# Altium schematic sheet [14] MISC.SchDoc("[14] MISC")
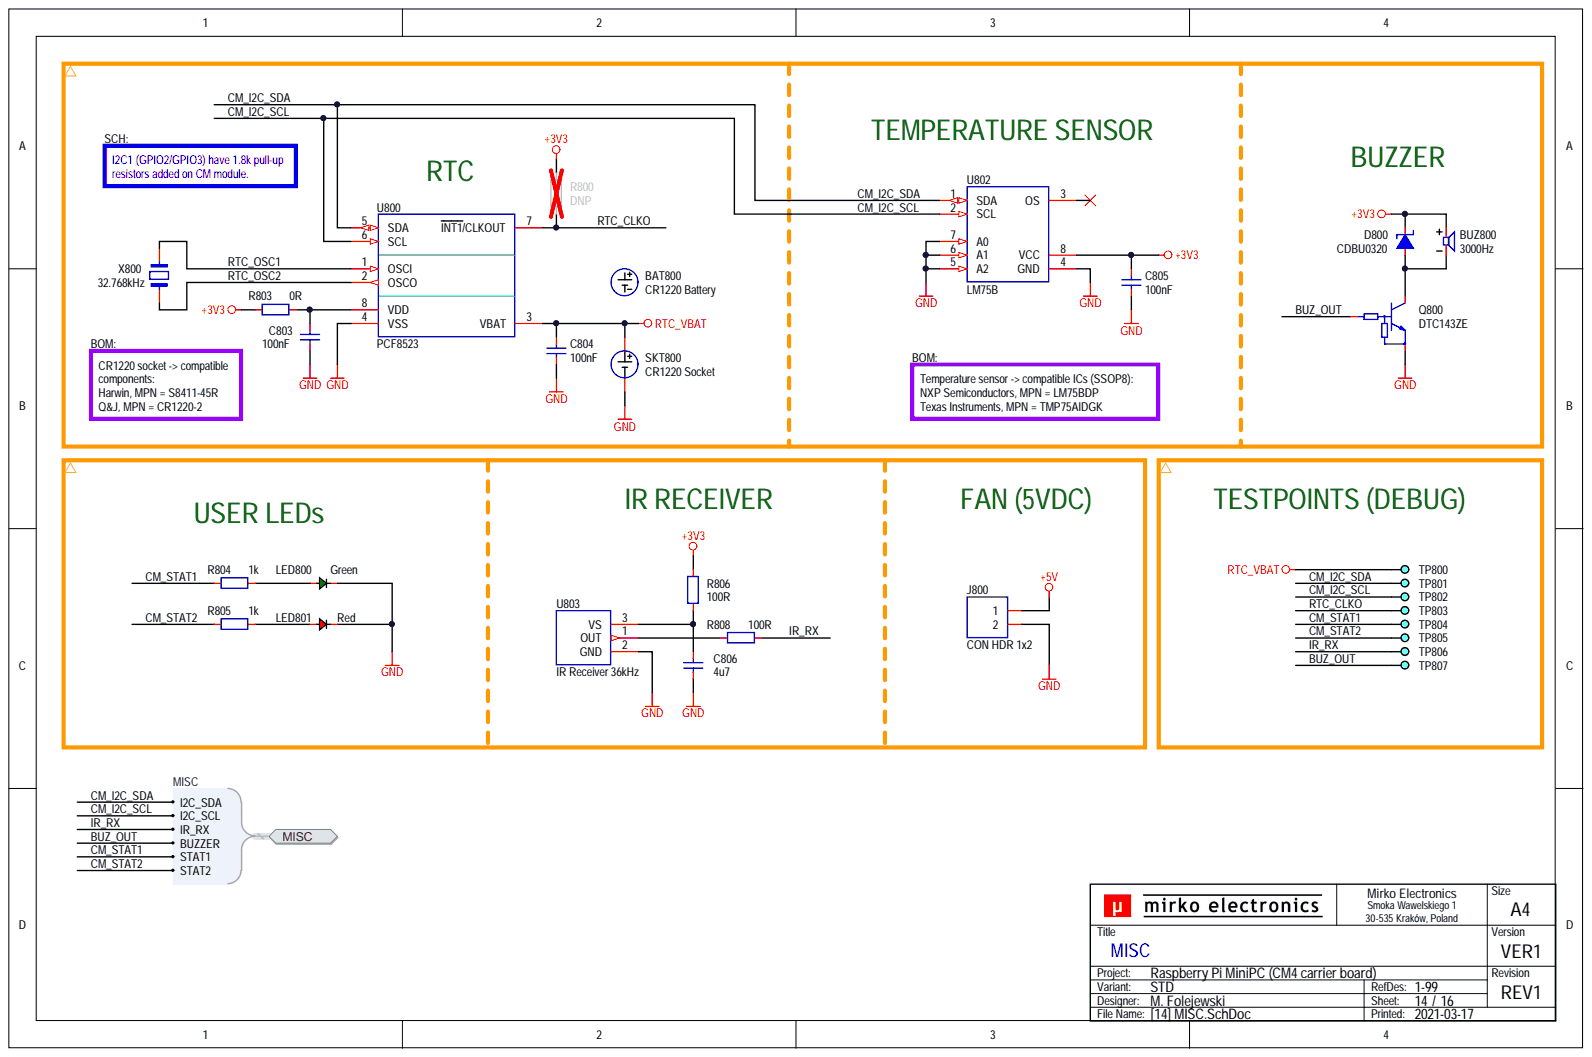
[diagram p0: [14] MISC.SchDoc]

## Parts
BAT800  CR1220 Battery  (mechanical)
BUZ800  3000Hz  [BUZ_960X960H500_R]
C803  100nF  [CAP_0603_R]
C804  100nF  [CAP_0603_R]
C805  100nF  [CAP_0603_R]
C806  4u7  [CAP_0603_R]
D800  CDBU0320  [DIO_0603_UNI_R]
J800  CON HDR 1x2  [CON_HDR_P254_1X02_STR]
LED800  Green  [LED_0805_GRN_R]
LED801  Red  [LED_0805_RED_R]
Q800  DTC143ZE  [PKG_SOT523_SC75_SOT416_P100_R]
R800  DNP  [RES_0603_R]
R803  0R  [RES_0603_R]
R804  1k  [RES_0603_R]
R805  1k  [RES_0603_R]
R806  100R  [RES_0603_R]
R808  100R  [RES_0603_R]
SKT800  CR1220 Socket  [SKT_HRW_S8411-45R]
TP800  DEBUG  [PCB_TP_DBG_50]  (value not drawn)  (no BOM)
TP801  DEBUG  [PCB_TP_DBG_50]  (value not drawn)  (no BOM)
TP802  DEBUG  [PCB_TP_DBG_50]  (value not drawn)  (no BOM)
TP803  DEBUG  [PCB_TP_DBG_50]  (value not drawn)  (no BOM)
TP804  DEBUG  [PCB_TP_DBG_50]  (value not drawn)  (no BOM)
TP805  DEBUG  [PCB_TP_DBG_50]  (value not drawn)  (no BOM)
TP806  DEBUG  [PCB_TP_DBG_50]  (value not drawn)  (no BOM)
TP807  DEBUG  [PCB_TP_DBG_50]  (value not drawn)  (no BOM)
U800  PCF8523  [ICC_SO8_SOP8_490X390X160_P127_R]
U802  LM75B  [ICC_TSSOP8_300X300X110P65]
U803  IR Receiver 36kHz  [OPT_VSH_TSOP3XXXX]
X800  32.768kHz

## Ports
MISC, MISC.BUZZER, MISC.I2C_SCL, MISC.I2C_SDA, MISC.IR_RX, MISC.STAT1, MISC.STAT2

## Nets
+3V3: BUZ800.2 C805.2 D800.1 R800.2 R803.1 R806.2 U802.8(VCC)
+5V: J800.1
GND: C803.1 C804.1 C805.1 C806.1 J800.2 LED800.1 LED801.1 Q800.2 SKT800.1 U800.4(VSS) U802.4(GND) U802.5(A2) U802.6(A1) U802.7(A0) U803.2
RTC_VBAT: C804.2 SKT800.2 TP800.1 U800.3(VBAT)
BUZ_OUT: Q800.1 TP807.1 ; port MISC
CM_I2C_SCL: TP802.1 U800.6(SCL) U802.2(SCL) ; port MISC
CM_I2C_SDA: TP801.1 U800.5(SDA) U802.1(SDA) ; port MISC
CM_STAT1: R804.1 TP804.1 ; port MISC
CM_STAT2: R805.1 TP805.1 ; port MISC
IR_RX: R808.1 TP806.1 ; port MISC
RTC_CLKO: R800.1 TP803.1 U800.7(INT1/CLKOUT)
RTC_OSC1: U800.1(OSCI) X800.4
RTC_OSC2: U800.2(OSCO) X800.1
NetBUZ800_1: BUZ800.1 D800.2 Q800.3
NetC803_2: C803.2 R803.2 U800.8(VDD)
NetC806_2: C806.2 R806.1 U803.3
NetLED800_2: LED800.2 R804.2
NetLED801_2: LED801.2 R805.2
NetR808_2: R808.2 U803.1

Not connected: U802 3(OS)

## Library data of the parts
BAT800 = BAT_RND: Battery; Round Type;; Value: CR1220 Battery; Library Name: MirFol_lib.SchLib
BUZ800 = BUZ_POL: Buzzer; MPN: FUET-9650B-3V; Manufacturer: FUET; Value: 3000Hz; Component Kind: Standard; Library Name: MirFol_lib.SchLib
C803-C805 = CAP-0008: Capacitor; MLCC; 100nF; TBD; TBD; TBD; TBD; SMD; 0603 (1608);; Database Table Name: CAPACITOR$; Voltage Rating: TBD; Value: 100nF; Tolerance: TBD; ROHS Status: TBD; Packaging Type: TBD; Package (Case): 0603 (1608); Operating Temperature: TBD; MPN: TBD; Mounting Type: SMD; Manufacturer: TBD; ID: CAP-0008; Features: TBD; Dielectric: TBD; Component Type: Capacitor; Component Subtype: MLCC; Component Kind: Standard; Component Height: TBD; Component Body: TBD; Capacitance: 100nF; Library Name: MirFol_db_local.DbLib
C806 = CAP-0023: Capacitor; MLCC; GENERIC; TBD; TBD; TBD; TBD; SMD; 0603 (1608);; Database Table Name: CAPACITOR$; Voltage Rating: TBD; Value: 4u7; Tolerance: TBD; ROHS Status: TBD; Packaging Type: TBD; Package (Case): 0603 (1608); Operating Temperature: TBD; MPN: GENERIC; Mounting Type: SMD; Manufacturer: GENERIC; ID: CAP-0023; Features: TBD; Dielectric: TBD; Component Type: Capacitor; Component Subtype: MLCC; Component Kind: Standard; Component Height: TBD; Component Body: TBD; Capacitance: GENERIC; Library Name: MirFol_db_local.DbLib
D800 = DIO_SHT_P2_KA: Diode; Schottky;; MPN: CDBU0320-HF; Manufacturer: Comchip Technology; Value: CDBU0320; Package: SMD 0603 (1608); Component Kind: Standard; Library Name: MirFol_lib.SchLib
J800 = CON-0020: Connector; Pin Header; 1 x 2 pins; 2.54mm; Male; TBD; TBD; THT; THT;; MPN: GENERIC; Database Table Name: CONNECTOR$; Value: CON HDR 1x2; ROHS Status: TBD; Pitch: 2.54mm; Pin Count: 2; Packaging Type: TBD; Package (Case): THT; Orientation: TBD; Operating Temperature: TBD; Mounting Type: THT; Manufacturer: GENERIC; ID: CON-0020; Features: TBD; Contact Rating: TBD; Contact Plating: TBD; Contact Gender: Male; Configuration: 1 x 2 pins; Component Type: Connector; Component Subtype: Pin Header; Component Kind: Standard; Component Height: TBD; Component Body: TBD; Library Name: MirFol_db_local.DbLib
LED800 = DIO_LED_GRN: Diode; LED; Green;; Value: Green; Component Kind: Standard; Library Name: MirFol_lib.SchLib
LED801 = DIO_LED_RED: Diode; LED; Red;; MPN: LED 0805 RED; Manufacturer: Generic; Value: Red; Component Kind: Standard; Library Name: MirFol_lib.SchLib
Q800 = TRA_BJT_NPN_DIG_BEC: Transistor; BJT; NPN; Digital;; Value: DTC143ZE; Component Kind: Standard; Library Name: MirFol_lib.SchLib
R800 = RES-0051: Resistor; Thick Film; DNP; TBD; TBD; TBD; TBD; DO NOT PLACE; SMD; 0603 (1608);; Database Table Name: RESISTOR$; Component Body: 1.60 x 0.80mm; Voltage Rating: TBD; Value: DNP; Tolerance: TBD; TCR: TBD; ROHS Status: TBD; Resistance: DNP; Power Rating: TBD; Packaging Type: TBD; Package (Case): 0603 (1608); Operating Temperature: TBD; MPN: TBD; Mounting Type: SMD; Manufacturer: TBD; ID: RES-0051; Features: DO NOT PLACE; Component Type: Resistor; Component Subtype: Thick Film; Component Kind: Standard; Component Height: TBD; Library Name: MirFol_db_local.DbLib
R803 = RES-0052: Resistor; Thick Film; 0R; 1%; TBD; TBD; TBD; Standard; SMD; 0603 (1608);; Database Table Name: RESISTOR$; Component Body: 1.60 x 0.80mm; Voltage Rating: TBD; Value: 0R; Tolerance: 1%; TCR: TBD; ROHS Status: TBD; Resistance: 0R; Power Rating: TBD; Packaging Type: TBD; Package (Case): 0603 (1608); Operating Temperature: TBD; MPN: TBD; Mounting Type: SMD; Manufacturer: TBD; ID: RES-0052; Features: Standard; Component Type: Resistor; Component Subtype: Thick Film; Component Kind: Standard; Component Height: 0.45mm; Library Name: MirFol_db_local.DbLib
R804 R805 = RES-0027: Resistor; Thick Film; 1k; 1%; 100mW; 100PPM; 75V; Standard; SMD; 0603 (1608);; MPN: TBD; Manufacturer: Yageo Corporation; Packaging Type: Tape & Reel; Database Table Name: RESISTOR$; Component Body: 1.60 x 0.80mm; Voltage Rating: 75V; Value: 1k; Tolerance: 1%; TCR: 100PPM; ROHS Status: RoHS Compliant; Resistance: 1k; Power Rating: 100mW; Package (Case): 0603 (1608); Operating Temperature: -55°C to +155°C; Mounting Type: SMD; ID: RES-0027; Features: Standard; Component Type: Resistor; Component Subtype: Thick Film; Component Kind: Standard; Component Height: 0.45mm; Library Name: MirFol_db_local.DbLib
R806 R808 = RES-0026: Resistor; Thick Film; 100R; 1%; 100mW; 100PPM; 75V; Standard; SMD; 0603 (1608);; MPN: TBD; Manufacturer: Yageo Corporation; Packaging Type: Tape & Reel; Database Table Name: RESISTOR$; Component Body: 1.60 x 0.80mm; Voltage Rating: 75V; Value: 100R; Tolerance: 1%; TCR: 100PPM; ROHS Status: RoHS Compliant; Resistance: 100R; Power Rating: 100mW; Package (Case): 0603 (1608); Operating Temperature: -55°C to +155°C; Mounting Type: SMD; ID: RES-0026; Features: Standard; Component Type: Resistor; Component Subtype: Thick Film; Component Kind: Standard; Component Height: 0.45mm; Library Name: MirFol_db_local.DbLib
SKT800 = SKT_BAT_RND_P2: Socket; Battery;; Value: CR1220 Socket; Component Kind: Standard; Library Name: MirFol_lib.SchLib
TP800-TP807 = PCB_TP_DBG: PCB Marking; Test Point (Debug);; Value: DEBUG; Library Name: MirFol_lib.SchLib
U800 = ICC-0010: IC; RTC; Real-Time Clock TBD; SMD; SO8/SOP8;; MPN: PCF8523T/1; Manufacturer: NXP Semiconductors; Pin Pitch: 1.27 mm; Packaging Type: Tape & Reel; Database Table Name: IC (MISC)$; Value: PCF8523; ROHS Status: TBD; Power Supply: TBD; Pin Count: 8; Package (Case): SO8/SOP8; Operating Temperature: TBD; Mounting Type: SMD; ID: ICC-0010; Features: Real-Time Clock; Component Type: IC; Component Subtype: RTC; Component Kind: Standard; Component Height: TBD; Component Body: TBD; Library Name: MirFol_db_local.DbLib
U802 = ICC_NXP_LM75B: ICC; Temperature Sensor;; MPN: LM75BDP; Manufacturer: NXP Semiconductors; Value: LM75B; Component Kind: Standard; Library Name: MirFol_lib.SchLib
U803 = OPT_VSH_TSOP34XXX: Opto; IR Receiver; MPN: TSOP34836; Manufacturer: Vishay; Value: IR Receiver 36kHz; Component Kind: Standard; Library Name: MirFol_lib.SchLib
X800 = OSC_XTL_P4_XNNX: Oscillator; Crystal;; Value: 32.768kHz; Component Kind: Standard; Library Name: MirFol_lib.SchLib
